# Altium schematic sheet Wzmocnienie.SchDoc
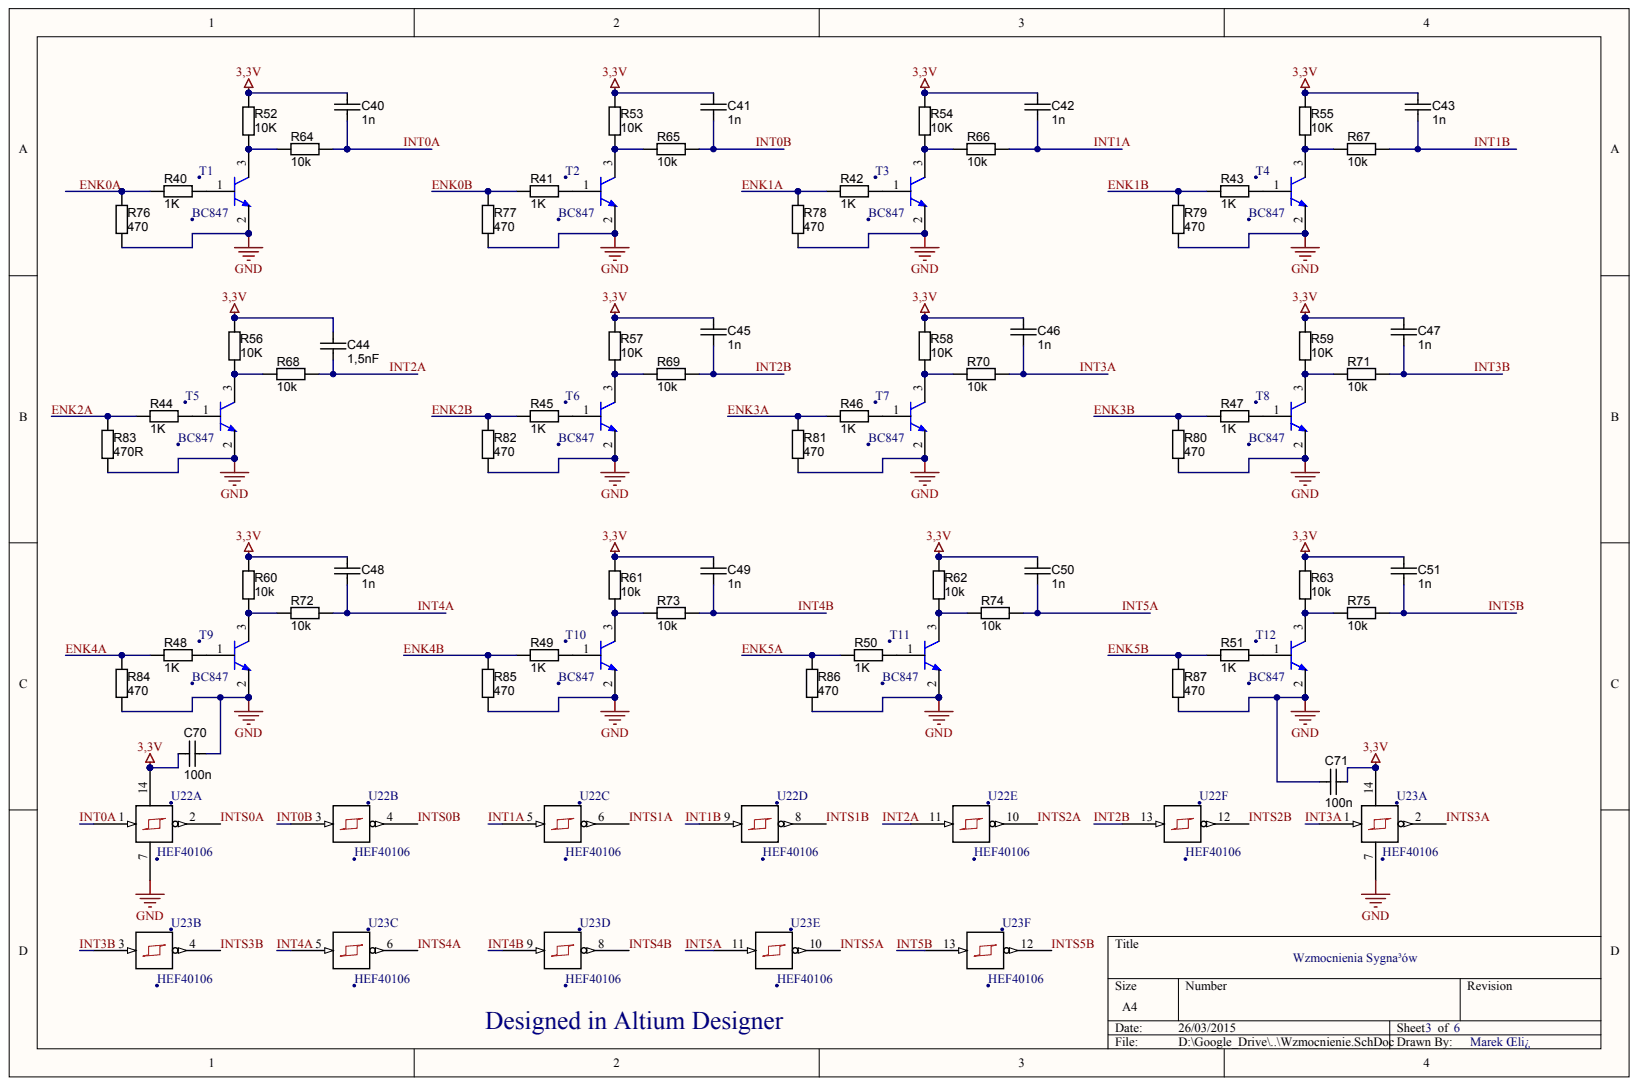
[diagram p0: Wzmocnienie.SchDoc]

## Parts
C40  value 1n  (hidden comment: approved sub OK)
C41  value 1n  (hidden comment: approved sub OK)
C42  value 1n  (hidden comment: approved sub OK)
C43  value 1n  (hidden comment: approved sub OK)
C44  value 1,5nF  (hidden comment: approved sub OK)
C45  value 1n  (hidden comment: approved sub OK)
C46  value 1n  (hidden comment: approved sub OK)
C47  value 1n  (hidden comment: approved sub OK)
C48  value 1n  (hidden comment: approved sub OK)
C49  value 1n  (hidden comment: approved sub OK)
C50  value 1n  (hidden comment: approved sub OK)
C51  value 1n  (hidden comment: approved sub OK)
C70  value 100n  (hidden comment: approved sub OK)
C71  value 100n  (hidden comment: approved sub OK)
R40  value 1K  (hidden comment: RES_EMPTY_0805)
R41  value 1K  (hidden comment: RES_EMPTY_0805)
R42  value 1K  (hidden comment: RES_EMPTY_0805)
R43  value 1K  (hidden comment: RES_EMPTY_0805)
R44  value 1K  (hidden comment: RES_EMPTY_0805)
R45  value 1K  (hidden comment: RES_EMPTY_0805)
R46  value 1K  (hidden comment: RES_EMPTY_0805)
R47  value 1K  (hidden comment: RES_EMPTY_0805)
R48  value 1K  (hidden comment: RES_EMPTY_0805)
R49  value 1K  (hidden comment: RES_EMPTY_0805)
R50  value 1K  (hidden comment: RES_EMPTY_0805)
R51  value 1K  (hidden comment: RES_EMPTY_0805)
R52  value 10K  (hidden comment: RES_EMPTY_0805)
R53  value 10K  (hidden comment: RES_EMPTY_0805)
R54  value 10K  (hidden comment: RES_EMPTY_0805)
R55  value 10K  (hidden comment: RES_EMPTY_0805)
R56  value 10K  (hidden comment: RES_EMPTY_0805)
R57  value 10K  (hidden comment: RES_EMPTY_0805)
R58  value 10K  (hidden comment: RES_EMPTY_0805)
R59  value 10K  (hidden comment: RES_EMPTY_0805)
R60  value 10k  (hidden comment: RES_EMPTY_0805)
R61  value 10k  (hidden comment: RES_EMPTY_0805)
R62  value 10k  (hidden comment: RES_EMPTY_0805)
R63  value 10k  (hidden comment: RES_EMPTY_0805)
R64  value 10k  (hidden comment: RES_EMPTY_0805)
R65  value 10k  (hidden comment: RES_EMPTY_0805)
R66  value 10k  (hidden comment: RES_EMPTY_0805)
R67  value 10k  (hidden comment: RES_EMPTY_0805)
R68  value 10k  (hidden comment: RES_EMPTY_0805)
R69  value 10k  (hidden comment: RES_EMPTY_0805)
R70  value 10k  (hidden comment: RES_EMPTY_0805)
R71  value 10k  (hidden comment: RES_EMPTY_0805)
R72  value 10k  (hidden comment: RES_EMPTY_0805)
R73  value 10k  (hidden comment: RES_EMPTY_0805)
R74  value 10k  (hidden comment: RES_EMPTY_0805)
R75  value 10k  (hidden comment: RES_EMPTY_0805)
R76  value 470  (hidden comment: RES_EMPTY_0805)
R77  value 470  (hidden comment: RES_EMPTY_0805)
R78  value 470  (hidden comment: RES_EMPTY_0805)
R79  value 470  (hidden comment: RES_EMPTY_0805)
R80  value 470  (hidden comment: RES_EMPTY_0805)
R81  value 470  (hidden comment: RES_EMPTY_0805)
R82  value 470  (hidden comment: RES_EMPTY_0805)
R83  value 470R  (hidden comment: RES_EMPTY_0805)
R84  value 470  (hidden comment: RES_EMPTY_0805)
R85  value 470  (hidden comment: RES_EMPTY_0805)
R86  value 470  (hidden comment: RES_EMPTY_0805)
R87  value 470  (hidden comment: RES_EMPTY_0805)
T1  BC847
T2  BC847
T3  BC847
T4  BC847
T5  BC847
T6  BC847
T7  BC847
T8  BC847
T9  BC847
T10  BC847
T11  BC847
T12  BC847
U22  HEF40106  (parts A,B,C,D,E,F)
U23  HEF40106  (parts A,B,C,D,E,F)

## Nets
3,3V: C40.1 C41.1 C42.1 C43.1 C44.1 C45.1 C46.1 C47.1 C48.1 C49.1 C50.1 C51.1 C70.1 C71.2 R52.2 R53.2 R54.2 R55.2 R56.2 R57.2 R58.2 R59.2 R60.1 R61.1 R62.1 R63.1 U22.14 U23.14
GND: C70.2 C71.1 R76.1 R77.1 R78.1 R79.1 R80.1 R81.1 R82.1 R83.1 R84.1 R85.1 R86.1 R87.1 T1.2 T2.2 T3.2 T4.2 T5.2 T6.2 T7.2 T8.2 T9.2 T10.2 T11.2 T12.2 U22.7 U23.7
ENK0A: R40.1 R76.2
ENK0B: R41.1 R77.2
ENK1A: R42.1 R78.2
ENK1B: R43.1 R79.2
ENK2A: R44.1 R83.2
ENK2B: R45.1 R82.2
ENK3A: R46.1 R81.2
ENK3B: R47.1 R80.2
ENK4A: R48.1 R84.2
ENK4B: R49.1 R85.2
ENK5A: R50.1 R86.2
ENK5B: R51.1 R87.2
INT0A: C40.2 R64.2 U22.1
INT0B: C41.2 R65.2 U22.3
INT1A: C42.2 R66.2 U22.5
INT1B: C43.2 R67.2 U22.9
INT2A: C44.2 R68.2 U22.11
INT2B: C45.2 R69.2 U22.13
INT3A: C46.2 R70.2 U23.1
INT3B: C47.2 R71.2 U23.3
INT4A: C48.2 R72.2 U23.5
INT4B: C49.2 R73.2 U23.9
INT5A: C50.2 R74.2 U23.11
INT5B: C51.2 R75.2 U23.13
INTS0A: U22.2
INTS0B: U22.4
INTS1A: U22.6
INTS1B: U22.8
INTS2A: U22.10
INTS2B: U22.12
INTS3A: U23.2
INTS3B: U23.4
INTS4A: U23.6
INTS4B: U23.8
INTS5A: U23.10
INTS5B: U23.12
NetR40_2: R40.2 T1.1
NetR41_2: R41.2 T2.1
NetR42_2: R42.2 T3.1
NetR43_2: R43.2 T4.1
NetR44_2: R44.2 T5.1
NetR45_2: R45.2 T6.1
NetR46_2: R46.2 T7.1
NetR47_2: R47.2 T8.1
NetR48_2: R48.2 T9.1
NetR49_2: R49.2 T10.1
NetR50_2: R50.2 T11.1
NetR51_2: R51.2 T12.1
NetR52_1: R52.1 R64.1 T1.3
NetR53_1: R53.1 R65.1 T2.3
NetR54_1: R54.1 R66.1 T3.3
NetR55_1: R55.1 R67.1 T4.3
NetR56_1: R56.1 R68.1 T5.3
NetR57_1: R57.1 R69.1 T6.3
NetR58_1: R58.1 R70.1 T7.3
NetR59_1: R59.1 R71.1 T8.3
NetR60_2: R60.2 R72.1 T9.3
NetR61_2: R61.2 R73.1 T10.3
NetR62_2: R62.2 R74.1 T11.3
NetR63_2: R63.2 R75.1 T12.3

## Library data of the parts
C40-C51 = (no symbol name): Manufacturer 1: AVX Corporation; Manufacturer Part Number 1: 0805ZD106KAT2A; Tolerance: ±10%; Ratings: 10V; X5R; Material: ceramic; Package / Case: 0805 (2012 metric); Manufacturer 2: Kemet; Manufacturer Part Number 2: C0805C106K8PACTU; Supplier 1: Digi-Key; Supplier Part Number 1: 478-5167-1-ND; ComponentLink1URL: http://www.avx.com/docs/Catalogs/cx5r.pdf; ComponentLink1Description: http://www.avx.com/docs/Catalogs/cx5r.pdf; ComponentLink2URL: http://www.kemet.com/datasheets&C0805C106K8PACTU; ComponentLink2Description: http://www.kemet.com/datasheets&C0805C106K8PACTU; Supplier 2: Digi-Key; Supplier Part Number 2: 399-4925-1-ND
C70 C71 = (no symbol name): Manufacturer 1: Kemet; Manufacturer Part Number 1: C0805C104K5RACTU; Tolerance: ±10%; Ratings: 50V; X7R; Material: ceramic; Package / Case: 0805 (2012 metric); Supplier 1: Digi-Key; Supplier Part Number 1: 399-1170-1-ND
R40-R87 T1-T12 U22 U23 = (no symbol name)
  R40-R87: Package / Case: 0805 (2012 metric)
